# Altium schematic sheet CN032-1 Industry EtherCAT Servo Controller_Interface.SchDoc
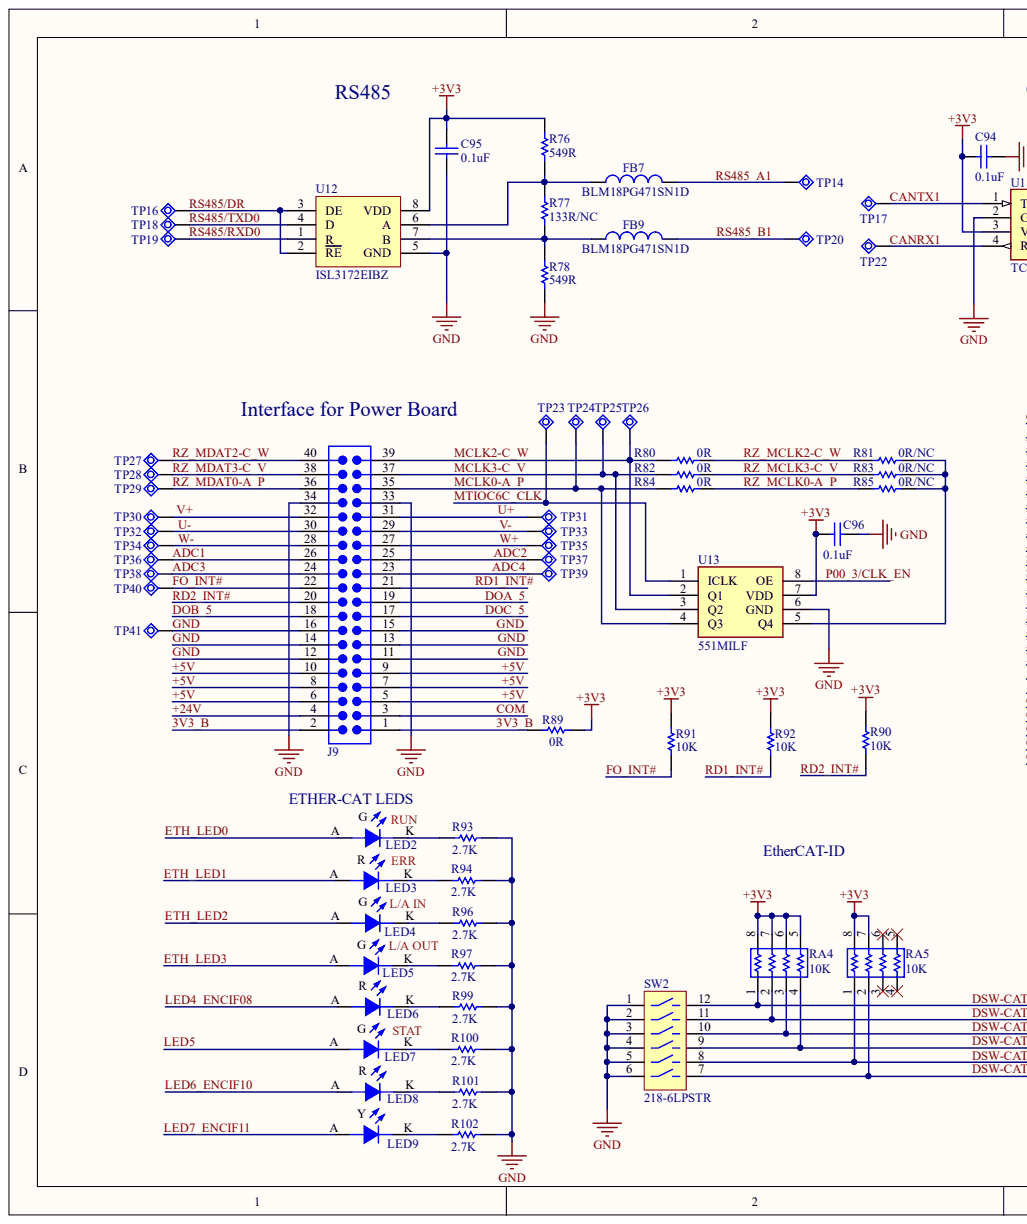
[diagram p0: CN032-1 Industry EtherCAT Servo Controller_Interface.SchDoc, left]
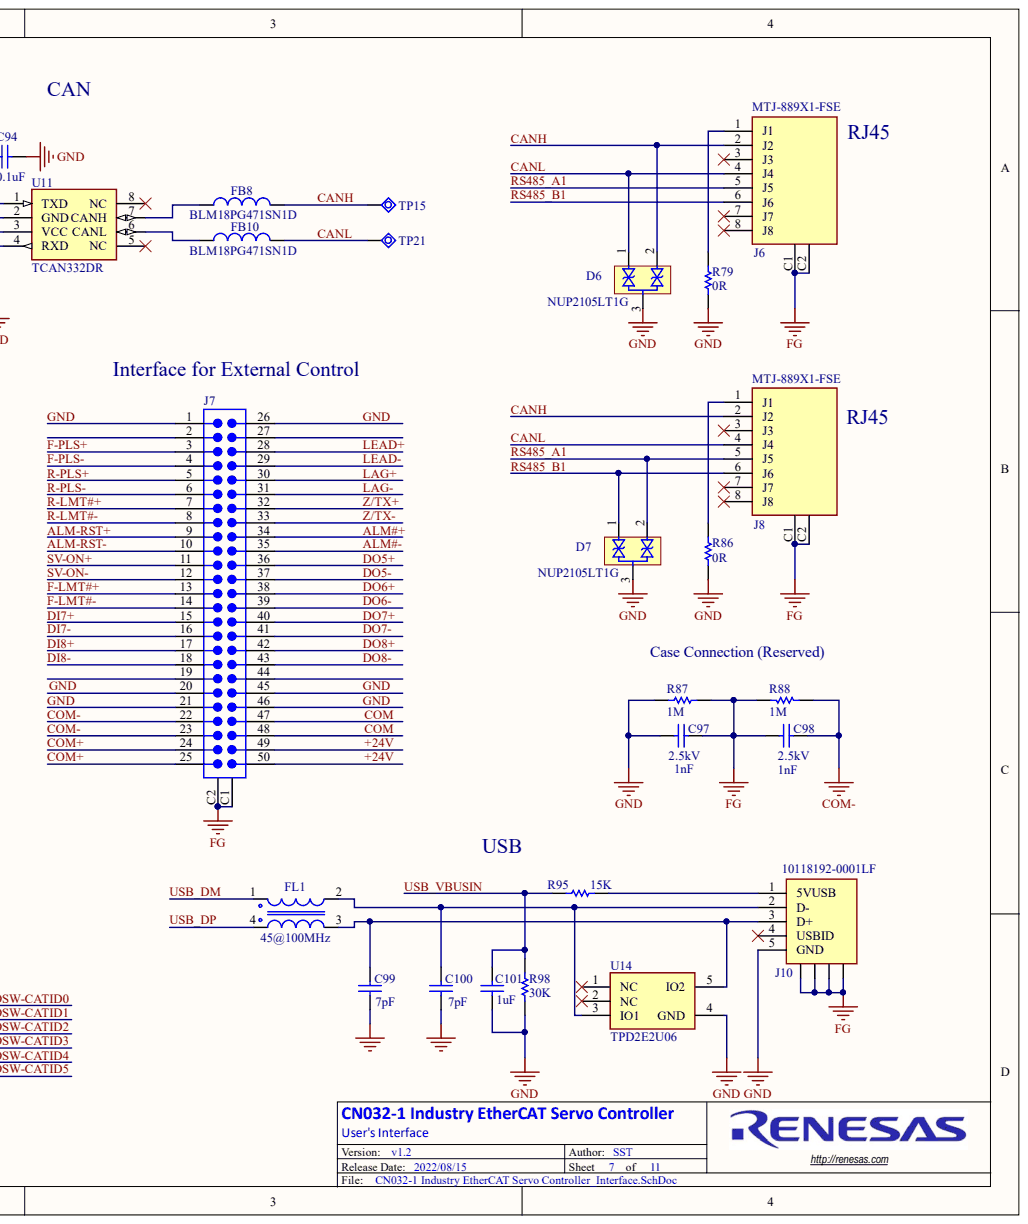
[diagram p1: CN032-1 Industry EtherCAT Servo Controller_Interface.SchDoc, right]

## Parts
C94  0.1uF  [CAPC0402-01]
C95  0.1uF  [CAPC0402-01]
C96  0.1uF  [CAPC0402-01]
C97  1nF  Voltage: 2.5kV  [CAPC1206-01]
C98  1nF  Voltage: 2.5kV  [CAPC1206-01]
C99  7pF  [CAPC0402-01]
C100  7pF  [CAPC0402-01]
C101  1uF  [CAPC0402-01]
D6  NUP2105LT1G  [SOT-23]
D7  NUP2105LT1G  [SOT-23]
FB7  BLM18PG471SN1D  [FB_0603]
FB8  BLM18PG471SN1D  [FB_0603]
FB9  BLM18PG471SN1D  [FB_0603]
FB10  BLM18PG471SN1D  [FB_0603]
FL1  value 45@100MHz  [FL0504]  (hidden comment: DLP11RN450UL2L)
J6  RJ45  [MTJ-889X1-FSE]
J7  HL-SCSI50HB90  [6368355-1]  (value not drawn)
J8  RJ45  [MTJ-889X1-FSE]
J9  61203921621  [61203921621 - new]  (value not drawn)
J10  Mfg Part Number: 10118192-0001LF  [USBSB5_6]  (hidden comment: USB Micro 2.0)
LED2  LED Green  [LED_0603-GRN]  (value not drawn)
LED3  LED Red  [LED_0603-RED]  (value not drawn)
LED4  LED Green  [LED_0603-GRN]  (value not drawn)
LED5  LED Green  [LED_0603-GRN]  (value not drawn)
LED6  LED Red  [LED_0603-RED]  (value not drawn)
LED7  LED Green  [LED_0603-GRN]  (value not drawn)
LED8  LED Red  [LED_0603-RED]  (value not drawn)
LED9  LED Yellow  [LED_0603-YLW]  (value not drawn)
R76  549R  [RESC0402-01]
R77  133R/NC  [RESC0402-01]
R78  549R  [RESC0402-01]
R79  0R  [RESC0603-01]
R80  0R  [RESC0402-01]
R81  0R/NC  [RESC0402-01]
R82  0R  [RESC0402-01]
R83  0R/NC  [RESC0402-01]
R84  0R  [RESC0402-01]
R85  0R/NC  [RESC0402-01]
R86  0R  [RESC0603-01]
R87  1M  [R2512 (6432)]
R88  1M  [R2512 (6432)]
R89  0R  [RESC0402-01]
R90  10K  [RESC0402-01]
R91  10K  [RESC0402-01]
R92  10K  [RESC0402-01]
R93  2.7K  [RESC0402-01]
R94  2.7K  [RESC0402-01]
R95  15K  [RESC0402-01]
R96  2.7K  [RESC0402-01]
R97  2.7K  [RESC0402-01]
R98  30K  [RESC0402-01]
R99  2.7K  [RESC0402-01]
R100  2.7K  [RESC0402-01]
R101  2.7K  [RESC0402-01]
R102  2.7K  [RESC0402-01]
RA4  10K  [RESCAF0804]
RA5  10K  [RESCAF0804]
SW2  218-6LPSTR  [SW_CTS_218-6LPST]
TP14  Test Point  [TEST POINT]  (value not drawn)
TP15  Test Point  [TEST POINT]  (value not drawn)
TP16  Test Point  [TEST POINT]  (value not drawn)
TP17  Test Point  [TEST POINT]  (value not drawn)
TP18  Test Point  [TEST POINT]  (value not drawn)
TP19  Test Point  [TEST POINT]  (value not drawn)
TP20  Test Point  [TEST POINT]  (value not drawn)
TP21  Test Point  [TEST POINT]  (value not drawn)
TP22  Test Point  [TEST POINT]  (value not drawn)
TP23  Test Point  [TEST POINT]  (value not drawn)
TP24  Test Point  [TEST POINT]  (value not drawn)
TP25  Test Point  [TEST POINT]  (value not drawn)
TP26  Test Point  [TEST POINT]  (value not drawn)
TP27  Test Point  [TEST POINT]  (value not drawn)
TP28  Test Point  [TEST POINT]  (value not drawn)
TP29  Test Point  [TEST POINT]  (value not drawn)
TP30  Test Point  [TEST POINT]  (value not drawn)
TP31  Test Point  [TEST POINT]  (value not drawn)
TP32  Test Point  [TEST POINT]  (value not drawn)
TP33  Test Point  [TEST POINT]  (value not drawn)
TP34  Test Point  [TEST POINT]  (value not drawn)
TP35  Test Point  [TEST POINT]  (value not drawn)
TP36  Test Point  [TEST POINT]  (value not drawn)
TP37  Test Point  [TEST POINT]  (value not drawn)
TP38  Test Point  [TEST POINT]  (value not drawn)
TP39  Test Point  [TEST POINT]  (value not drawn)
TP40  Test Point  [TEST POINT]  (value not drawn)
TP41  Test Point  [TEST POINT]  (value not drawn)
U11  TCAN332DR  [TI_SOIC8]
U12  ISL3172EIBZ  [SOIC-8 (150-mil)]
U13  551MILF  [SOIC-8 (150-mil)]
U14  Display Name: TPD2E2U06  [SOT-553]  (hidden comment: TPD2E2U06DRLR)

## Nets
+3V3: C94.1 C95.1 C96.1 R76.1 R89.2 R90.2 R91.2 R92.2 RA4.5 RA4.6 RA4.7 RA4.8 RA5.7 RA5.8 U11.3(VCC) U12.8(VDD) U13.7(VDD)
FG: C97.1 C98.1 J6.C1 J6.C2 J7.C1 J7.C2 J8.C1 J8.C2 J10.C1 J10.C2 J10.C3 J10.C4 R87.1 R88.1
3V3_B: J9.1 J9.2 R89.1
+5V: J9.5 J9.6 J9.7 J9.8 J9.9 J9.10
+24V: J7.49 J7.50 J9.4
ADC1: J9.26 TP36.1
ADC2: J9.25 TP37.1
ADC3: J9.24 TP38.1
ADC4: J9.23 TP39.1
ALM#+: J7.34
ALM#-: J7.35
ALM-RST+: J7.9
ALM-RST-: J7.10
CANH: D6.2 FB8.1 J6.2(J2) J8.2(J2) TP15.1
CANL: D6.1 FB10.1 J6.4(J4) J8.4(J4) TP21.1
CANRX1: TP22.1 U11.4(RXD)
CANTX1: TP17.1 U11.1(TXD)
COM: J7.47 J7.48 J9.3
COM+: J7.24 J7.25
COM-: C98.2 J7.22 J7.23 R88.2
DI7+: J7.15
DI7-: J7.16
DI8+: J7.17
DI8-: J7.18
DO5+: J7.36
DO5-: J7.37
DO6+: J7.38
DO6-: J7.39
DO7+: J7.40
DO7-: J7.41
DO8+: J7.42
DO8-: J7.43
DOA_5: J9.19
DOB_5: J9.18
DOC_5: J9.17
DSW-CATID0: RA4.1 SW2.12
DSW-CATID1: RA4.2 SW2.11
DSW-CATID2: RA4.3 SW2.10
DSW-CATID3: RA4.4 SW2.9
DSW-CATID4: RA5.1 SW2.8
DSW-CATID5: RA5.2 SW2.7
ETH_LED0: LED2.A
ETH_LED1: LED3.A
ETH_LED2: LED4.A
ETH_LED3: LED5.A
F-LMT#+: J7.13
F-LMT#-: J7.14
F-PLS+: J7.3
F-PLS-: J7.4
FO_INT#: J9.22 R91.1 TP40.1
GND: C94.2 C95.2 C96.2 C97.2 C99.1 C100.1 C101.1 D6.3 D7.3 J7.1 J7.20 J7.21 J7.26 J7.45 J7.46 J9.11 J9.12 J9.13 J9.14 J9.15 J9.16 J9.33 J9.34 J10.5(GND) R78.2 R79.1 R86.1 R87.2 R93.2 R94.2 R96.2 R97.2 R98.1 R99.2 R100.2 R101.2 R102.2 SW2.1 SW2.2 SW2.3 SW2.4 SW2.5 SW2.6 TP41.1 U11.2(GND) U12.5(GND) U13.6(GND) U14.4(GND)
LAG+: J7.30
LAG-: J7.31
LEAD+: J7.28
LEAD-: J7.29
LED4_ENCIF08: LED6.A
LED5: LED7.A
LED6_ENCIF10: LED8.A
LED7_ENCIF11: LED9.A
MCLK0-A_P: J9.35 R84.1 TP24.1 U13.4(Q3)
MCLK2-C_W: J9.39 R80.1 TP26.1 U13.2(Q1)
MCLK3-C_V: J9.37 R82.1 TP25.1 U13.3(Q2)
MTIOC6C_CLK: TP23.1 U13.1(ICLK)
P00_3/CLK_EN: U13.8(OE)
R-LMT#+: J7.7
R-LMT#-: J7.8
R-PLS+: J7.5
R-PLS-: J7.6
RD1_INT#: J9.21 R92.1
RD2_INT#: J9.20 R90.1
RS485/DR: TP16.1 U12.2(RE) U12.3(DE)
RS485/RXD0: TP19.1 U12.1(R)
RS485/TXD0: TP18.1 U12.4(D)
RS485_A1: D7.2 FB7.1 J6.5(J5) J8.5(J5) TP14.1
RS485_B1: D7.1 FB9.1 J6.6 J8.6(J6) TP20.1
RZ_MCLK0-A_P: R84.2 R85.1
RZ_MCLK2-C_W: R80.2 R81.1
RZ_MCLK3-C_V: R82.2 R83.1
RZ_MDAT0-A_P: J9.36 TP29.1
RZ_MDAT2-C_W: J9.40 TP27.1
RZ_MDAT3-C_V: J9.38 TP28.1
SV-ON+: J7.11
SV-ON-: J7.12
U+: J9.31 TP31.1
U-: J9.30 TP32.1
USB_DM: FL1.1
USB_DP: FL1.4
USB_VBUSIN: C101.2 R95.1 R98.2
V+: J9.32 TP30.1
V-: J9.29 TP33.1
W+: J9.27 TP35.1
W-: J9.28 TP34.1
Z/TX+: J7.32
Z/TX-: J7.33
NetC99_2: C99.2 FL1.3 J10.3(D+) U14.5(IO2)
NetC100_2: C100.2 FL1.2 J10.2(D-) U14.3(IO1)
NetFB7_2: FB7.2 R76.2 R77.2 U12.6(A)
NetFB8_2: FB8.2 U11.7(CANH)
NetFB9_2: FB9.2 R77.1 R78.1 U12.7(B)
NetFB10_2: FB10.2 U11.6(CANL)
NetJ6_1: J6.1(J1) R79.2
NetJ8_1: J8.1(J1) R86.2
NetJ10_1: J10.1(5VUSB) R95.2
NetLED2_K: LED2.K R93.1
NetLED3_K: LED3.K R94.1
NetLED4_K: LED4.K R96.1
NetLED5_K: LED5.K R97.1
NetLED6_K: LED6.K R99.1
NetLED7_K: LED7.K R100.1
NetLED8_K: LED8.K R101.1
NetLED9_K: LED9.K R102.1
NetR81_2: R81.2 R83.2 R85.2 U13.5(Q4)

Not connected: J6 3(J3) 7(J7) 8(J8); J7 2 19 27 44; J8 3(J3) 7(J7) 8; J10 4(USBID); RA5 3 4 5 6; U11 5(NC) 8(NC); U14 1(NC) 2(NC)

## Library data of the parts
C94-C96 = CAP-0402-0.1uF-YAGEO: Ceramic Chip Capacitor 0402 0.1uF 16V; Manufacturer: YAGEO; Mfg Part Number: CC0402KPX7R7BB104; Dialectric: X7R; Tolerance: 10%; Capacitance: 0.1uF; Component Kind: Standard; Package: 0402; Voltage: 16V; Library Name: Synergy.SchLib
C97 C98 = CAP-1206-1nF: Ceramic Chip Capacitor 1206 1nF 2.5kV; Manufacturer: TDK; Mfg Part Number: CC1206KKX7RDBB102; Dialectric: X7R; Tolerance: 10%; Capacitance: 1nF; Component Kind: Standard; Package: 1206; Library Name: Synergy.SchLib
C99 C100 = CAP-0402-7pF: Ceramic Chip Capacitor 0402 7pF 50V; Manufacturer: YAGEO; Mfg Part Number: CC0402DRNPO9BN7R0; Dialectric: C0G; Tolerance: ±0.5pF; Capacitance: 7pF; Component Kind: Standard; Package: 0402; Voltage: 50V; Library Name: Synergy.SchLib
C101 = CAP-0402-1uF: Ceramic Chip Capacitor 0402 1uF 16V; Manufacturer: YAGEO; Mfg Part Number: CC0402KRX5R7BB105; Dialectric: X5R; Tolerance: 10%; Capacitance: 1uF; Component Kind: Standard; Package: 0402; Voltage: 16V; Library Name: Synergy.SchLib
D6 D7 = DIO-NUP2105LT1G: Dual Line CAN Bus Protector 350W; Manufacturer: OnSemi; Current: 8A; Voltage: 32V; Component Kind: Standard; Display Name: NUP2105LT1G; Mfg Part Number: NUP2105LT1G; Package: SOT-23; Library Name: Synergy.SchLib
FB7-FB10 = FB-BLM18PG471SN1D: Ferrite Bead,470ohm@100MHz,1A,SMD0603; Manufacturer: Murata; Resistance: 200mΩ; Component Kind: Standard; Current: 1A; Mfg Part Number: BLM18PG471SN1D; Package: 0603; Library Name: Synergy.SchLib
FL1 = FL-DLP11RN450UL2L: Inductor Common Mode Choke 45Ohm 25% 100MHz 100mA 0504 Embossed T/R; Manufacturer: Murata; Component Kind: Standard; Current: 100mA; Mfg Part Number: DLP11RN450UL2L; Library Name: Synergy.SchLib
J6 J8 = CON-RJ45 1x1 RS485: MODULAR JACK RJ45 8P8C; Manufacturer: Adam Tech; Component Kind: Standard; Mfg Part Number: MTJ-889X1-FSE; Library Name: Synergy.SchLib
J7 = CON-2x25 6368355-1: CONN RCPT 50POS R/A SOLDER; Manufacturer: HLing煌林; Pitch: 淘宝; Positions: 50; Component Kind: Standard; Mfg Part Number: HL-SCSI50HB90; Library Name: Synergy.SchLib
J9 = CON-2.54mm 2x20 Male_1: Header Male 2.54mm 40pos 2x20; Manufacturer: Würth Elektronik; Positions: 40; Component Kind: Standard; Mfg Part Number: 61203921621; Pitch: 2.54mm; Library Name: Synergy.IntLib
J10 = USB-UBAF-0608: Connector,MicroUSB B port,5 Position,Right Angle,Gold Flash 1u,black,SMD; Manufacturer: FCI; Component Kind: Standard; Part Type: USB Connector; PCB Footprint: USBSB5_6; Library Name: Synergy.SchLib
LED2 LED4 LED5 LED7 = LED-0603-Green: Lite-On LED Green Clear 0603; Mfg Part Number: LTST-C191KGKT; Serious P/N: LED-000004-01; Component Kind: Standard; Manufacturer: Lite-On; Package: 0603; Library Name: Synergy.SchLib
LED3 LED6 LED8 = LED-0603-Red: Lite-On LED Red Clear 0603; Mfg Part Number: LTST-C191KRKT; Component Kind: Standard; Manufacturer: Lite-On; Package: 0603; Library Name: Synergy.SchLib
LED9 = LED-0603-Yellow: Lite-On LED Yellow Clear 0603; Mfg Part Number: LTST-C191KSKT; Component Kind: Standard; Manufacturer: Lite-On; Package: 0603; Library Name: Synergy.SchLib
R76 R78 = RES-0402-549R: Chip Resistor Thick Film 0402 549R 1% 1/16W; Manufacturer: YAGEO; Mfg Part Number: RC0402FR-07549RL; Component Kind: Standard; Package: 0402; Power: 1/16W; Resistance: 549R; Tolerance: 1%; Library Name: Synergy.SchLib
R77 = RES-0402-133R: Chip Resistor Thick Film 0402 133R 1% 1/16W; Manufacturer: YAGEO; Mfg Part Number: RC0402FR-07133RL; Component Kind: Standard; Package: 0402; Power: 1/16W; Resistance: 133R/NC; Tolerance: 1%; Library Name: Synergy.SchLib
R79 R86 = RES-0603-0R: Chip Resistor Thick Film 0603 0R 1% 1/10W; Manufacturer: YAGEO; Mfg Part Number: RC0603FR-070RL; Component Kind: Standard; Package: 0603; Power: 1/10W; Resistance: 0R; Tolerance: 1%; Library Name: Synergy.SchLib
R80-R85 R89 = RES-0402-0R: Chip Resistor Thick Film 0402 0R 1% 1/16W; Manufacturer: YAGEO; Mfg Part Number: RC0402JR-070RL; Component Kind: Standard; Package: 0402; Power: 1/16W; Tolerance: 1%
  R80 R82 R84 R89: Resistance: 0R
  R81 R83 R85: Resistance: 0R/NC
  R80-R85: Library Name: Synergy.SchLib
  R89: Library Name: Synergy.IntLib
R87 R88 = RES-2512-1M: Chip Resistor Thick Film 2512 1M 5% 1W; Manufacturer: YAGEO; Mfg Part Number: RC2512JK-071ML; Power: 2W; Component Kind: Standard; Package: 2512; Resistance: 1M; Tolerance: 5%; Library Name: Synergy.SchLib
R90-R92 = RES-0402-10K: Chip Resistor Thick Film 0402 10K 1% 1/16W; Manufacturer: YAGEO; Mfg Part Number: RC0402FR-0710KL; Component Kind: Standard; Package: 0402; Power: 1/16W; Resistance: 10K; Tolerance: 1%; Library Name: Synergy.SchLib
R93 R94 R96 R97 R99-R102 = RES-0402-2.7K: Chip Resistor Thick Film 0402 2.7K 1% 1/16W; Manufacturer: YAGEO; Mfg Part Number: RC0402FR-072K7L; Component Kind: Standard; Package: 0402; Power: 1/16W; Resistance: 2.7K; Tolerance: 1%; Library Name: Synergy.SchLib
R95 = RES-0402-15K: Chip Resistor Thick Film 0402 15K 1% 1/16W; Manufacturer: YAGEO; Mfg Part Number: RC0402FR-0715KL; Component Kind: Standard; Package: 0402; Power: 1/16W; Resistance: 15K; Tolerance: 1%; Library Name: Synergy.SchLib
R98 = RES-0402-30K: Chip Resistor Thick Film 0402 30K 1% 1/16W; Manufacturer: YAGEO; Mfg Part Number: RC0402FR-0730KL; Component Kind: Standard; Package: 0402; Power: 1/16W; Resistance: 30K; Tolerance: 1%; Library Name: Synergy.SchLib
RA4 RA5 = RES-0804-10K_1: Chip Resistor Array 0804 10K 5% 1/16W 4 x 0402; Manufacturer: YAGEO; Mfg Part Number: YC124-JR-0710KL; Component Kind: Standard; Package: 0804; Power: 1/16W; Resistance: 10K; Tolerance: 5%; Library Name: CN268 IEEE-1588.SchLib
SW2 = PSW_218-6LPSTR: SW 6P SPST DIP 25mA 24V SMT; Manufacturer: CTS; Component Kind: Standard; Mfg Part Number: 218-6LPSTR; Part Type: DIP Switch; Value: 218-6LPSTR; Library Name: CN268 IEEE-1588.SchLib
TP14-TP41 = CON-Test Point: Component Kind: Standard; Description: Test Point; Library Name: Synergy.SchLib
U11 = ICN-TCAN332DR: IC TRANSCEIVER 1/1 8SOIC; Manufacturer: TI; Component Kind: Standard; Display Name: TCAN332DR; Mfg Part Number: TCAN332DR; Package: SOIC-8; Library Name: Synergy.SchLib
U12 = ICN-ISL3172EIBZ-T: IC TRANSCEIVER HALF 1/1 8SOIC; Manufacturer: Renesas; Component Kind: Standard; Display Name: ISL3172EIBZ; Mfg Part Number: ISL3172EIBZ; Package: 8-SOIC（0.154"，3.90mm 宽）; Library Name: Synergy.SchLib
U13 = ICN-551MILF: IC CLK BUFFER 1:4 160MHZ 8SOIC; Manufacturer: Renesas; Component Kind: Standard; Display Name: 551MILF; Mfg Part Number: 551MILF; Package: 8SOP; Library Name: Synergy.SchLib
U14 = ICN-TPD2E2U06: TVS DIODE 5.5V 12.4V SOT5; Manufacturer: TI; Component Kind: Standard; Mfg Part Number: TPD2E2U06DRLR; Package: SOT-553; Library Name: Synergy.SchLib
